# Altium schematic sheet Motor driver.SchDoc
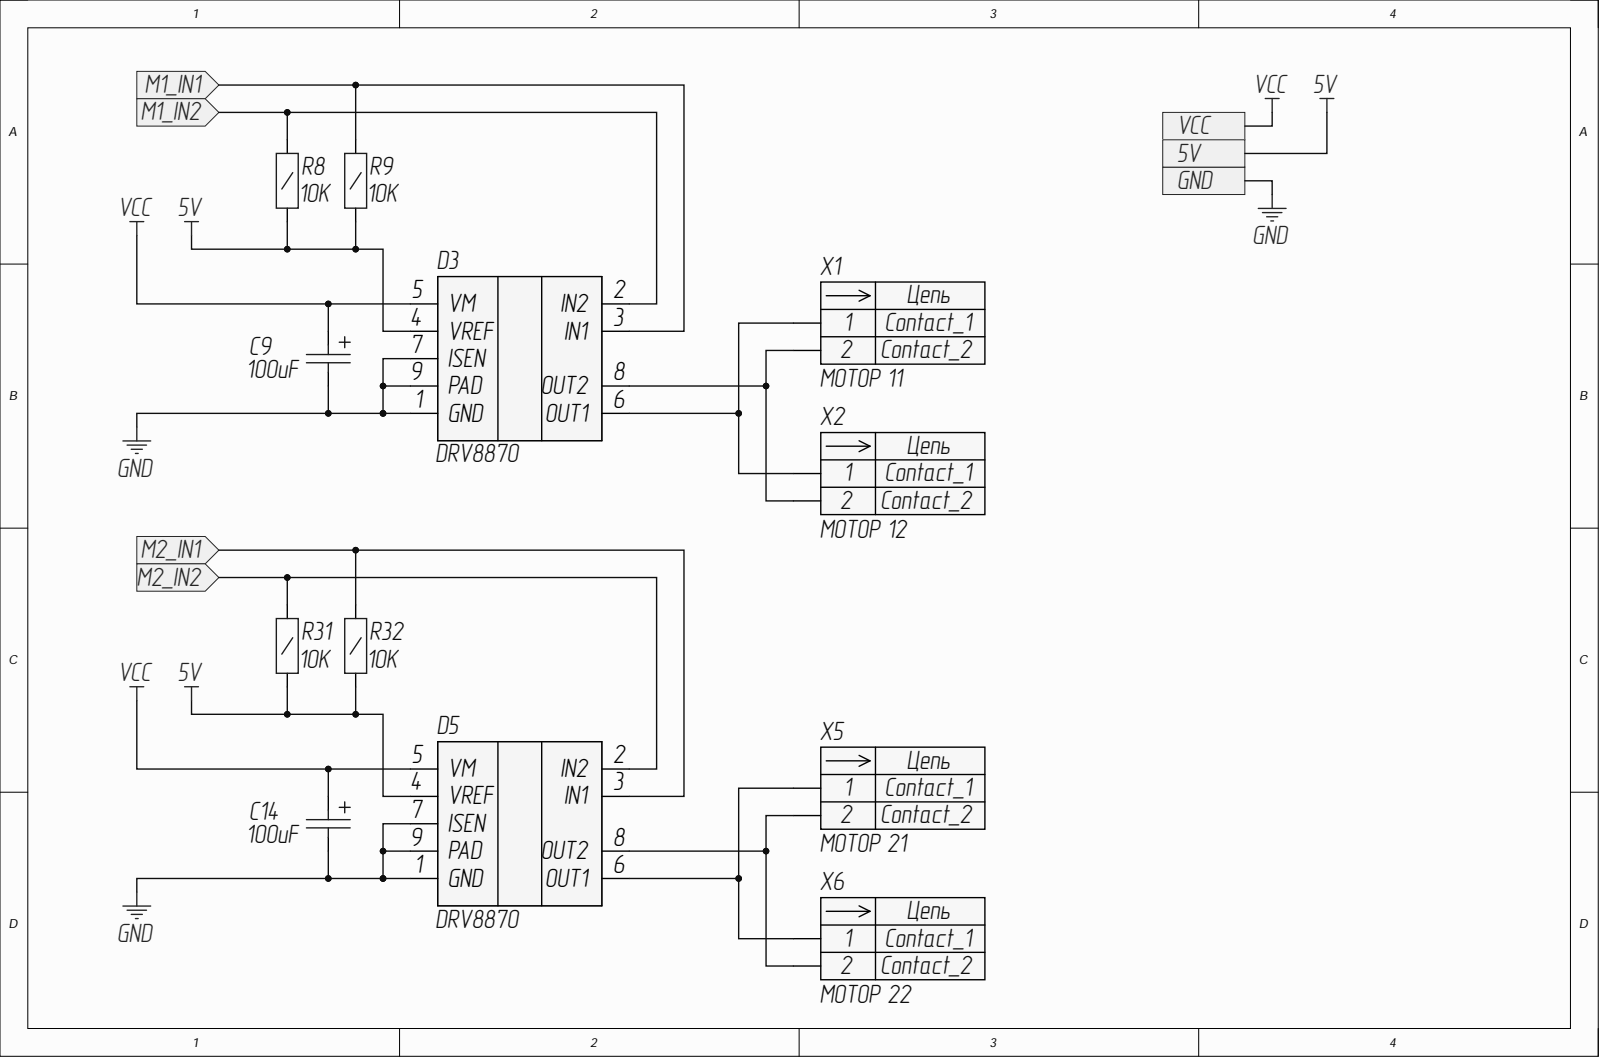
[diagram p0: Motor driver.SchDoc]

## Parts
C9  100uF  [TANTAL CAPACITOR C]
C14  100uF  [TANTAL CAPACITOR C]
D3  DRV8870  [SOIC8 WITH THERMAL PAD]
D5  DRV8870  [SOIC8 WITH THERMAL PAD]
R8  10K  [R0603]
R9  10K  [R0603]
R31  10K  [R0603]
R32  10K  [R0603]
X1  МОТОР 11  [KLS2-301-5.00 WITH LABEL]
X2  МОТОР 12  [KLS2-301-5.00 WITH LABEL]
X5  МОТОР 21  [KLS2-301-5.00 WITH LABEL]
X6  МОТОР 22  [KLS2-301-5.00 WITH LABEL]

## Ports
5V, GND, M1_IN1, M1_IN2, M2_IN1, M2_IN2, VCC

## Nets
5V: D3.4(VREF) D5.4(VREF) R8.1 R9.1 R31.1 R32.1 ; port 5V
GND: C9.2 C14.2 D3.1(GND) D3.7(ISEN) D3.9(PAD) D5.1(GND) D5.7(ISEN) D5.9(PAD) ; port GND
M1_IN1: D3.3(IN1) R9.2 ; port M1_IN1
M1_IN2: D3.2(IN2) R8.2 ; port M1_IN2
M2_IN1: D5.3(IN1) R32.2 ; port M2_IN1
M2_IN2: D5.2(IN2) R31.2 ; port M2_IN2
VCC: C9.1 C14.1 D3.5(VM) D5.5(VM) ; port VCC
NetD3_6: D3.6(OUT1) X1.1 X2.1
NetD3_8: D3.8(OUT2) X1.2 X2.2
NetD5_6: D5.6(OUT1) X5.1 X6.1
NetD5_8: D5.8(OUT2) X5.2 X6.2

## Library data of the parts
C9 C14 = Capacitor tantal: Конденсатор пол.; Component Kind: Standard; Library Name: LibSCH.SchLib; Value: 100uF
D3 D5 = DRV8870: Драйвер моторов; Component Kind: Standard; Library Name: LibSCH.SchLib
R8 R9 R31 R32 = Резистор  0,25Вт: Резистор 0,25Вт; Power rating: 0,25Вт; Component Kind: Standard; Library Name: LibSCH.SchLib; Value: 10K
X1 X2 X5 X6 = Header_2_plg: Разъем - 2 конт. вилка; Component Kind: Standard; Contact_1: Contact_1; Contact_2: Contact_2; Library Name: LibSCH.SchLib
  X1: Name: МОТОР 11
  X2: Name: МОТОР 12
  X5: Name: МОТОР 21
  X6: Name: МОТОР 22
